# Altium schematic sheet ADC.SchDoc(ADC)
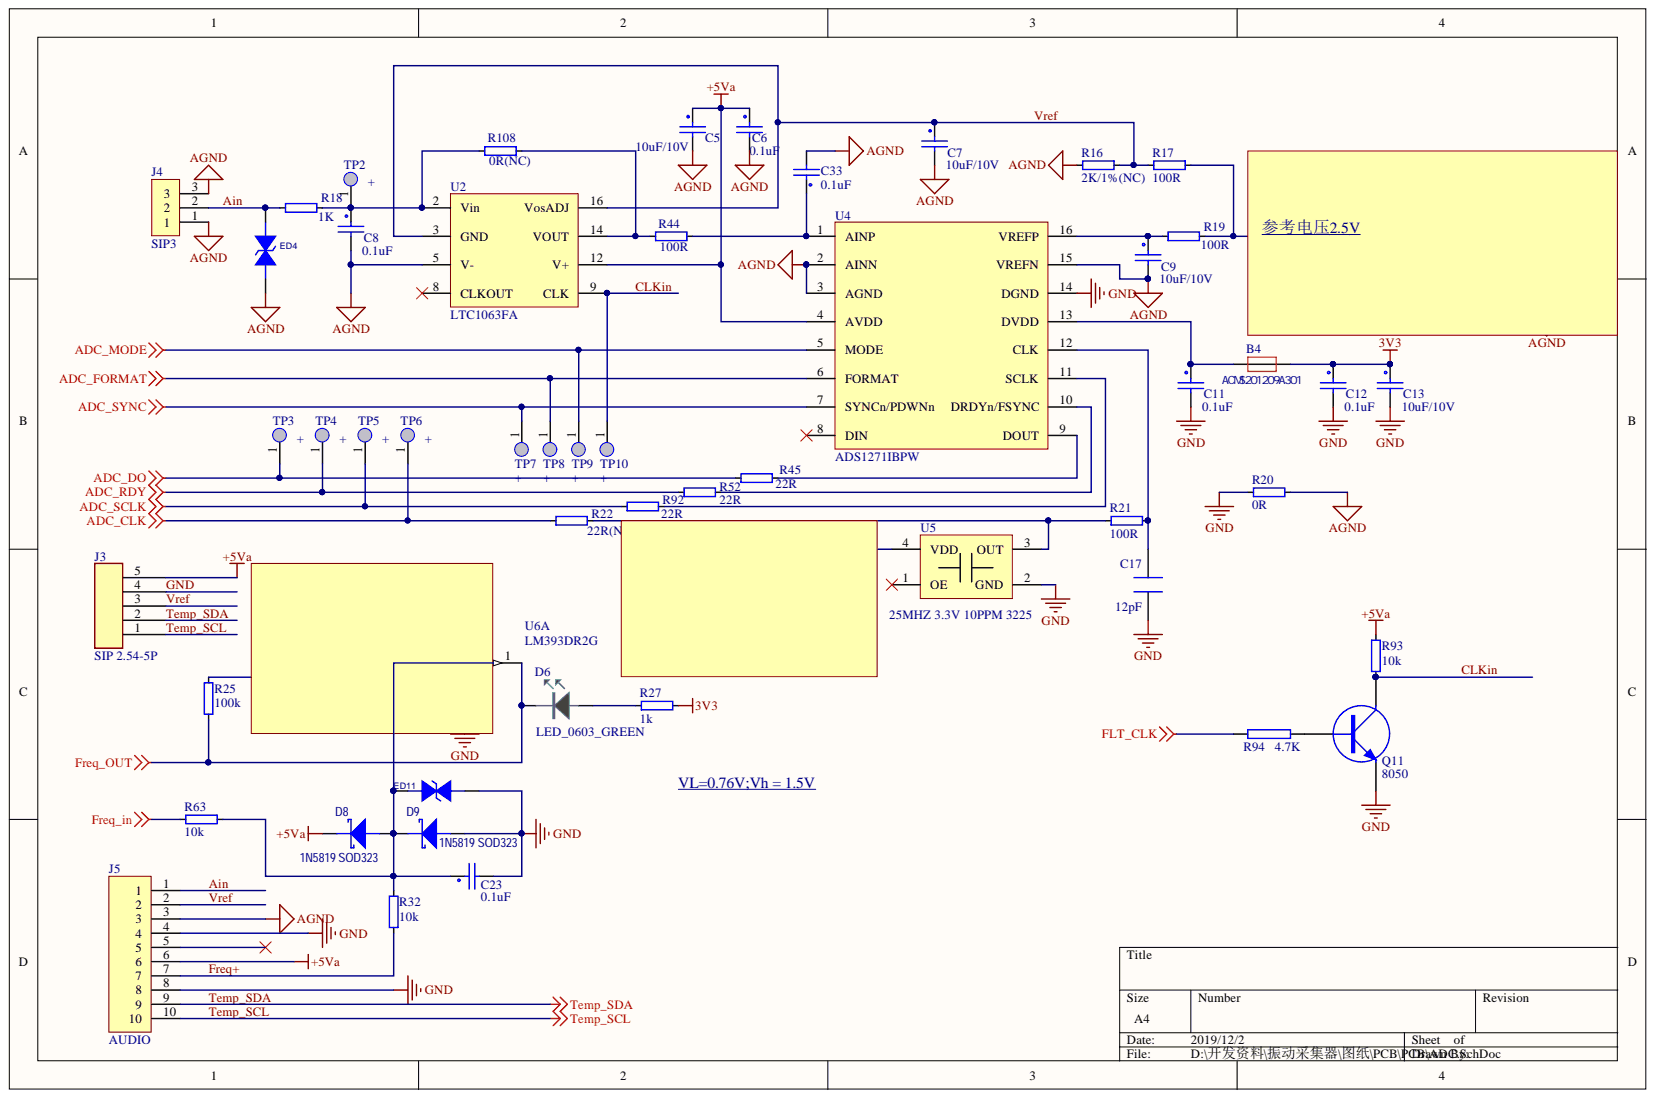
[diagram p0: ADC.SchDoc]

## Parts
B1  ACMS201209A301  [0805_R]
B4  ACMS201209A301  [0805_R]
C5  10uF/10V  [0603_C]
C6  0.1uF  [0402_C]
C7  10uF/10V  [0603_C]
C8  0.1uF  [0402_C]
C9  10uF/10V  [0603_C]
C10  10uF/10V  [0603_C]
C11  0.1uF  [0402_C]
C12  0.1uF  [0402_C]
C13  10uF/10V  [0603_C]
C14  47uF/10V  [0805_C]
C15  0.1uF  [0402_C]
C16  0.1uF  [0402_C]
C17  12pF  [0402_C]
C18  47pF  [0402_C]
C23  0.1uF  [0402_C]
C33  0.1uF  [0402_C]
C73  1uF/10V  [0402_C]
D6  LED_0603_GREEN  [0603_LED_S1]
D8  1N5819 SOD323  [SOD-323]
D9  1N5819 SOD323  [SOD-323]
ED4  ESD3Z5.0C  [SOD323]  (value not drawn)
ED11  ESD3Z5.0C  [SOD323]  (value not drawn)
J3  SIP 2.54-5P  [CON5]
J4  SIP3  [SIP3]
J5  AUDIO  [FPC1020FG-10P]
Q11  8050  [SOT-23(SOT-23-3)]
R16  2K/1%(NC)  [0402]
R17  100R  [0402]
R18  1K  [0402]
R19  100R  [0402]
R20  0R  [0402]
R21  100R  [0402]
R22  22R(NC)  [0402]
R23  100k  [0402]
R24  100k  [0402]
R25  100k  [0402]
R26  22k  [0402]
R27  1k  [0402]
R32  10k  [0402]
R44  100R  [0402]
R45  22R  [0402]
R52  22R  [0402]
R63  10k  [0402]
R92  22R  [0402]
R93  10k  [0402]
R94  4.7K  [0402_R]
R108  0R(NC)  [0402]
TP2  +  [TP]
TP3  +  [TP]
TP4  +  [TP]
TP5  +  [TP]
TP6  +  [TP]
TP7  +  [TP]
TP8  +  [TP]
TP9  +  [TP]
TP10  +  [TP]
U2  LTC1063FA  [SOP16]
U3  REF5025AIDR  [SO-8-A]
U4  ADS1271IBPW  [TSSOP-16_16PIN-L5.10-W6.60-PITCH0.65-BL]
U5  25MHZ 3.3V 10PPM 3225  [XTAL_3.2X2.5MM]
U6  LM393DR2G  [SOIC-8_150mil]  (part A)

## Nets
3V3: B1.1 B4.2 C12.1 C13.1 C14.1 R27.1
+5VA: C5.1 C6.1 C10.1 D8.C J3.5 J5.6 R23.1 R24.1 R93.2 U2.12(V+) U3.2(Vin) U4.4(AVDD) U6.8
ADC_CLK: R22.2 TP6.1
ADC_DO: R45.2 TP3.1
ADC_FORMAT: TP8.1 U4.6(FORMAT)
ADC_MODE: TP9.1 U4.5(MODE)
ADC_RDY: R52.2 TP4.1
ADC_SCLK: R92.2 TP5.1
ADC_SYNC: TP7.1 U4.7(SYNCn/PDWNn)
AGND: C5.2 C6.2 C7.2 C8.2 C9.2 C10.2 C33.2 C73.2 ED4.2 J4.1 J4.3 J5.3 R16.1 R20.1 U2.5(V-) U3.4(GND) U4.2(AINN) U4.3(AGND) U4.15(VREFN)
FLT_CLK: R94.2
FREQ_IN: R63.1
FREQ_OUT: D6.K R25.1 U6.1
AIN: ED4.1 J4.2 J5.1 R18.2
CLKIN: Q11.3 R93.1 TP10.1 U2.9(CLK)
FREQ+: J5.7 R32.2
GND: C11.2 C12.2 C13.2 C14.2 C15.2 C16.2 C17.2 C18.2 C23.2 D9.A ED11.2 J3.4 J5.4 J5.8 Q11.2 R20.2 R26.2 U4.14(DGND) U5.2(GND) U6.4
TEMP_SCL: J3.1 J5.10
TEMP_SDA: J3.2 J5.9
VREF: C7.1 J3.3 J5.2 R16.2 R17.1 U2.3(GND) U2.16(VosADJ)
NetB1_2: B1.2 C15.1 C16.1 U5.4(VDD)
NetB4_1: B4.1 C11.1 U4.13(DVDD)
NetC8_1: C8.1 R18.1 R108.2 TP2.1 U2.2(Vin)
NetC9_1: C9.1 R19.2 U4.16(VREFP)
NetC17_1: C17.1 R21.1 U4.12(CLK)
NetC18_1: C18.1 R24.2 R25.2 R26.1 U6.3
NetC23_1: C23.1 D8.A D9.C ED11.1 R23.2 R32.1 R63.2 U6.2
NetC33_1: C33.1 R44.1 U4.1(AINP)
NetC73_1: C73.1 U3.5(TRIM/NR)
NetD6_A: D6.A R27.2
NetQ11_1: Q11.1 R94.1
NetR17_2: R17.2 R19.1 U3.6(Vout)
NetR21_2: R21.2 R22.1 U5.3(OUT)
NetR44_2: R44.2 R108.1 U2.14(VOUT)
NetR45_1: R45.1 U4.9(DOUT)
NetR52_1: R52.1 U4.10(DRDYn/FSYNC)
NetR92_1: R92.1 U4.11(SCLK)

Not connected: J5 5; U2 8(CLKOUT); U3 1(DNC) 3(TEMP) 7(NC) 8(DNC); U4 8(DIN); U5 1(OE)

## Library data of the parts
B1 B4 = Bead: Component Kind: Standard; Library Name: RP3288BV03_20180126-.SCHLIB
C5 C7 C9 C10 C13 C73 = CAP_100N_25V_0402_10%_X5R: 100nF; MANUFACTURER: TAIYO YUDEN; PART: TMK105BJ104KV-F; Component Kind: Standard; FARNELL: 1611916; Library Name: Arbury_C.SchLib
C6 C8 C11 C12 C23 C33 = CAP_100N_25V_0402_10%_X5R: MANUFACTURER: TAIYO YUDEN; PART: TMK105BJ104KV-F; Component Kind: Standard; Description: 0.1uF; FARNELL: 1611916; Library Name: Arbury_C.SchLib
C14 = STD-06-0048: CHIP CAPACITOR, CERAMIC, 100NF, 16V, 10%, X7R, 0402; Manufacturer: Yageo; Manufacturer Part Number: CC0402KRX7R7BB104; Approx Volume Cost ($): 0.003; Database Table Name: MLCC$; VALUE: 100N; Component Kind: Standard; Dielectric: X7R; Part Number: STD-06-0048; Rated Voltage: 16V; Tolerance: 10%; Library Name: JG_StandardLibrary.DbLib
C15 C16 = STD-06-0048: CHIP CAPACITOR, CERAMIC, 100NF, 16V, 10%, X7R, 0402; Manufacturer: Yageo; Manufacturer Part Number: CC0402KRX7R7BB104; Approx Volume Cost ($): 0.003; Database Table Name: MLCC$; Component Kind: Standard; Dielectric: X7R; Part Number: STD-06-0048; Rated Voltage: 16V; Tolerance: 10%; VALUE: 0.1uF; Library Name: JG_StandardLibrary.DbLib
C17 = STD-06-0048: CHIP CAPACITOR, CERAMIC, 100NF, 16V, 10%, X7R, 0402; Manufacturer: Yageo; Manufacturer Part Number: CC0402KRX7R7BB104; Approx Volume Cost ($): 0.003; Database Table Name: MLCC$; VALUE: 0.1; Component Kind: Standard; Dielectric: X7R; Part Number: STD-06-0048; Rated Voltage: 16V; Tolerance: 10%; Library Name: JG_StandardLibrary.DbLib
C18 = CAP: Capacitor; Component Kind: Standard
D6 = LED_0603_GREEN: MANUFACTURER: KINGBRIGHT; PART: KP-3216CGCK; Component Kind: Standard; Description: LED_0603_GREEN; FARNELL: 2290333; Library Name: Arbury_SCH.SchLib
D8 D9 = DIO-SCH: Diode schottky; Component Kind: Standard; Library Name: Diodes_cn.SCHLIB
ED4 ED11 = DIODE BI-DIR TRIG: ESD; Component Kind: Standard
J3 = CON5: Component Kind: Standard; Library Name: 下位机控制板.SCHLIB
J5 = CON10: Component Kind: Standard; Library Name: main_lib.SchLib
Q11 = NPN: NPN Transistor; Component Kind: Standard
R16-R27 R32 R44 R45 R52 R63 R92 R93 R108 = R0402-12k: Resistor, ?%, 0.063W; Artikkelnr Noca: 27049099; Database Table Name: Resistors$; Note: Chip Res 1%; Value: 12k; Component Kind: Standard; LatestRevisionDate: 2009-11-23; Library Name: Nordic Passive DB.DbLib; Part Number: R0402-12k; Pin Count: 2; Published: 2009-05-08; Supplier: Farnell; Supplier part number: 173-8865; Publisher: Nordic Semiconductor
R94 = RES2: Component Kind: Standard
TP2-TP10 = TP: Component Kind: Standard; Library Name: mysch.SchLib
U3 = REF50XX: Component Kind: Standard; Library Name: RT1052_CORE_P120.lib
U4 = ADS1271: Component Kind: Standard; Library Name: RT1052_CORE_P120.lib
U5 = OSC3225: Component Kind: Standard; Library Name: 4MIC.SCHLIB
U6 = LM393DR2G_[C7955]: 电压比较器; Component Kind: Standard; ComponentLink1Description: 供应商链接; ComponentLink1URL: http://www.szlcsc.com/product/details_8432.html; Library Name: IC.SchLib; Package: SOIC-8; Supplier: LC; SuppliersPartNumber: C7955
J4 = SIP3: Component Kind: Standard; Library Name: PCB_Project.SCHLIB
U2 = LTC1063FA: Component Kind: Standard; Library Name: 振动采集器.SCHLIB
